# Altium schematic sheet [5] RGBs_1.SchDoc("[5] RGBs_1")
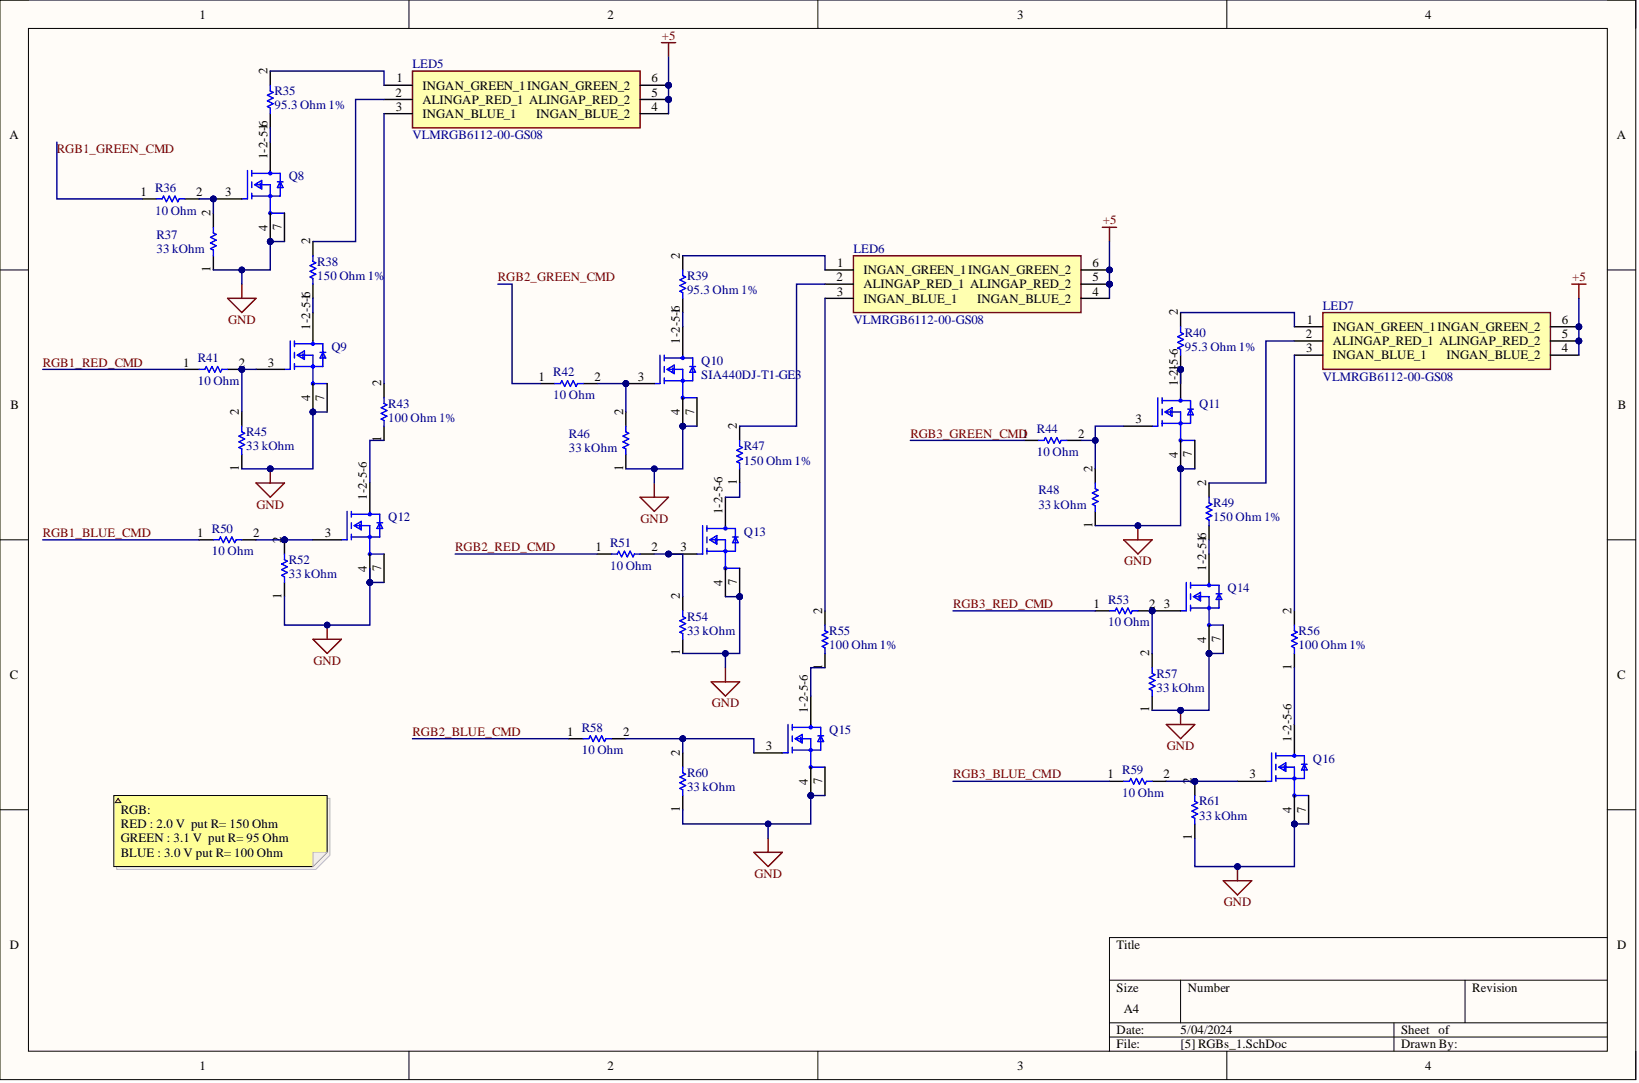
[diagram p0: [5] RGBs_1.SchDoc]

## Parts
LED5  VLMRGB6112-00-GS08
LED6  VLMRGB6112-00-GS08
LED7  VLMRGB6112-00-GS08
Q8  SIA440DJ-T1-GE3
Q9  SIA440DJ-T1-GE3
Q10  SIA440DJ-T1-GE3
Q11  SIA440DJ-T1-GE3
Q12  SIA440DJ-T1-GE3
Q13  SIA440DJ-T1-GE3
Q14  SIA440DJ-T1-GE3
Q15  SIA440DJ-T1-GE3
Q16  SIA440DJ-T1-GE3
R35  95.3 Ohm 1%
R36  10 Ohm
R37  33 kOhm
R38  150 Ohm 1%
R39  95.3 Ohm 1%
R40  95.3 Ohm 1%
R41  10 Ohm
R42  10 Ohm
R43  100 Ohm 1%
R44  10 Ohm
R45  33 kOhm
R46  33 kOhm
R47  150 Ohm 1%
R48  33 kOhm
R49  150 Ohm 1%
R50  10 Ohm
R51  10 Ohm
R52  33 kOhm
R53  10 Ohm
R54  33 kOhm
R55  100 Ohm 1%
R56  100 Ohm 1%
R57  33 kOhm
R58  10 Ohm
R59  10 Ohm
R60  33 kOhm
R61  33 kOhm

## Nets
+5: LED5.4(INGAN_BLUE_2) LED5.5(ALINGAP_RED_2) LED5.6(INGAN_GREEN_2) LED6.4(INGAN_BLUE_2) LED6.5(ALINGAP_RED_2) LED6.6(INGAN_GREEN_2) LED7.4(INGAN_BLUE_2) LED7.5(ALINGAP_RED_2) LED7.6(INGAN_GREEN_2)
GND: Q8.4 Q8.7 Q9.4 Q9.7 Q10.4 Q10.7 Q11.4 Q11.7 Q12.4 Q12.7 Q13.4 Q13.7 Q14.4 Q14.7 Q15.4 Q15.7 Q16.4 Q16.7 R37.1 R45.1 R46.1 R48.1 R52.1 R54.1 R57.1 R60.1 R61.1
RGB1_BLUE_CMD: R50.1
RGB1_GREEN_CMD: R36.1
RGB1_RED_CMD: R41.1
RGB2_BLUE_CMD: R58.1
RGB2_GREEN_CMD: R42.1
RGB2_RED_CMD: R51.1
RGB3_BLUE_CMD: R59.1
RGB3_GREEN_CMD: R44.1
RGB3_RED_CMD: R53.1
NetLED5_1: LED5.1(INGAN_GREEN_1) R35.2
NetLED5_2: LED5.2(ALINGAP_RED_1) R38.2
NetLED5_3: LED5.3(INGAN_BLUE_1) R43.2
NetLED6_1: LED6.1(INGAN_GREEN_1) R39.2
NetLED6_2: LED6.2(ALINGAP_RED_1) R47.2
NetLED6_3: LED6.3(INGAN_BLUE_1) R55.2
NetLED7_1: LED7.1(INGAN_GREEN_1) R40.2
NetLED7_2: LED7.2(ALINGAP_RED_1) R49.2
NetLED7_3: LED7.3(INGAN_BLUE_1) R56.2
NetQ8_1-2-5-6: Q8.1-2-5-6 R35.1
NetQ8_3: Q8.3 R36.2 R37.2
NetQ9_1-2-5-6: Q9.1-2-5-6 R38.1
NetQ9_3: Q9.3 R41.2 R45.2
NetQ10_1-2-5-6: Q10.1-2-5-6 R39.1
NetQ10_3: Q10.3 R42.2 R46.2
NetQ11_1-2-5-6: Q11.1-2-5-6 R40.1
NetQ11_3: Q11.3 R44.2 R48.2
NetQ12_1-2-5-6: Q12.1-2-5-6 R43.1
NetQ12_3: Q12.3 R50.2 R52.2
NetQ13_1-2-5-6: Q13.1-2-5-6 R47.1
NetQ13_3: Q13.3 R51.2 R54.2
NetQ14_1-2-5-6: Q14.1-2-5-6 R49.1
NetQ14_3: Q14.3 R53.2 R57.2
NetQ15_1-2-5-6: Q15.1-2-5-6 R55.1
NetQ15_3: Q15.3 R58.2 R60.2
NetQ16_1-2-5-6: Q16.1-2-5-6 R56.1
NetQ16_3: Q16.3 R59.2 R61.2

## Library data of the parts
LED5-LED7 = CMP-031-000019-1: Vishay VLMRGB6112-00-GS08; Arrow Part Number: VLMRGB6112-00-GS08; Arrow Price/Stock: https://www.arrow.com/en/products/vlmrgb6112-00-gs08/vishay?region=nac; Component Kind: Standard; Datasheet Link: https://www.vishay.com/docs/84342/vlmrgb6112.pdf; Design Item ID: VLMRGB6112-00-GS08; Height: 1.45mm; Manufacturer_Name: Vishay; Manufacturer_Part_Number: VLMRGB6112-00-GS08; Mouser Part Number: 78-VLMRGB6112-00GS08; Mouser Price/Stock: https://www.mouser.co.uk/ProductDetail/Vishay-Semiconductors/VLMRGB6112-00-GS08?qs=r0GWHu4zPW7d7ePE7SUMgQ%3D%3D; Source: SamacSys.SchLib; Library Name: EMEA- Squadra Corse PoliTO-  Politecnico di Torino
Q8-Q16 = CMP-02550-000315-1: MOSFET N-CH 40V 12A PPAK SC70-6; Drain to Source Resistance: 26mR; Element Configuration: Single; Fall Time: 5ns; Gate to Source Voltage (Vgs): 12V; Input Capacitance: 700pF; Max Power Dissipation: 19W; Number of Channels: 1; Polarity: N-CHANNEL; Rise Time: 32ns; Turn-Off Delay Time: 23ns; Turn-On Delay Time: 12ns; Component Kind: Standard; Continuous Drain Current (ID): 12A; Drain to Source Breakdown Voltage: 40V; Drain to Source Voltage (Vdss): 40V; Height: 0.75mm; Length: 2.05mm; Library Name: Altium Content Vault; Max Operating Temperature: 150°C; Min Operating Temperature: -55°C; Mount: Surface Mount; Number of Pins: 6; Package Quantity: 3000; Packaging: Tape and Reel; Radiation Hardening: No; Rds On Max: 26mR; RoHS Compliant: Yes; Series: TrenchFET®; Width: 2.05mm
R35 R39 R40 = CMP-00010-3: CRCW060395R3FKTA; Component Kind: Standard; Library Name: EMEA- Squadra Corse PoliTO-  Politecnico di Torino
R36 R41 R42 R44 R50 R51 R53 R58 R59 = CMP-00010-3: CRCW060310R0FKEAHP; Component Kind: Standard; Library Name: EMEA- Squadra Corse PoliTO-  Politecnico di Torino
R37 R45 R46 R48 R52 R54 R57 R60 R61 = CMP-00010-3: CRCW060333K0JNEA; Component Kind: Standard; Library Name: EMEA- Squadra Corse PoliTO-  Politecnico di Torino
R38 R47 R49 = CMP-00010-3: CRCU0603150RFKEAHP; Component Kind: Standard; Library Name: EMEA- Squadra Corse PoliTO-  Politecnico di Torino
R43 R55 R56 = CMP-00010-3: CRCW0603100RFKEAHP; Component Kind: Standard; Library Name: EMEA- Squadra Corse PoliTO-  Politecnico di Torino
